# Altium schematic sheet charger.SchDoc
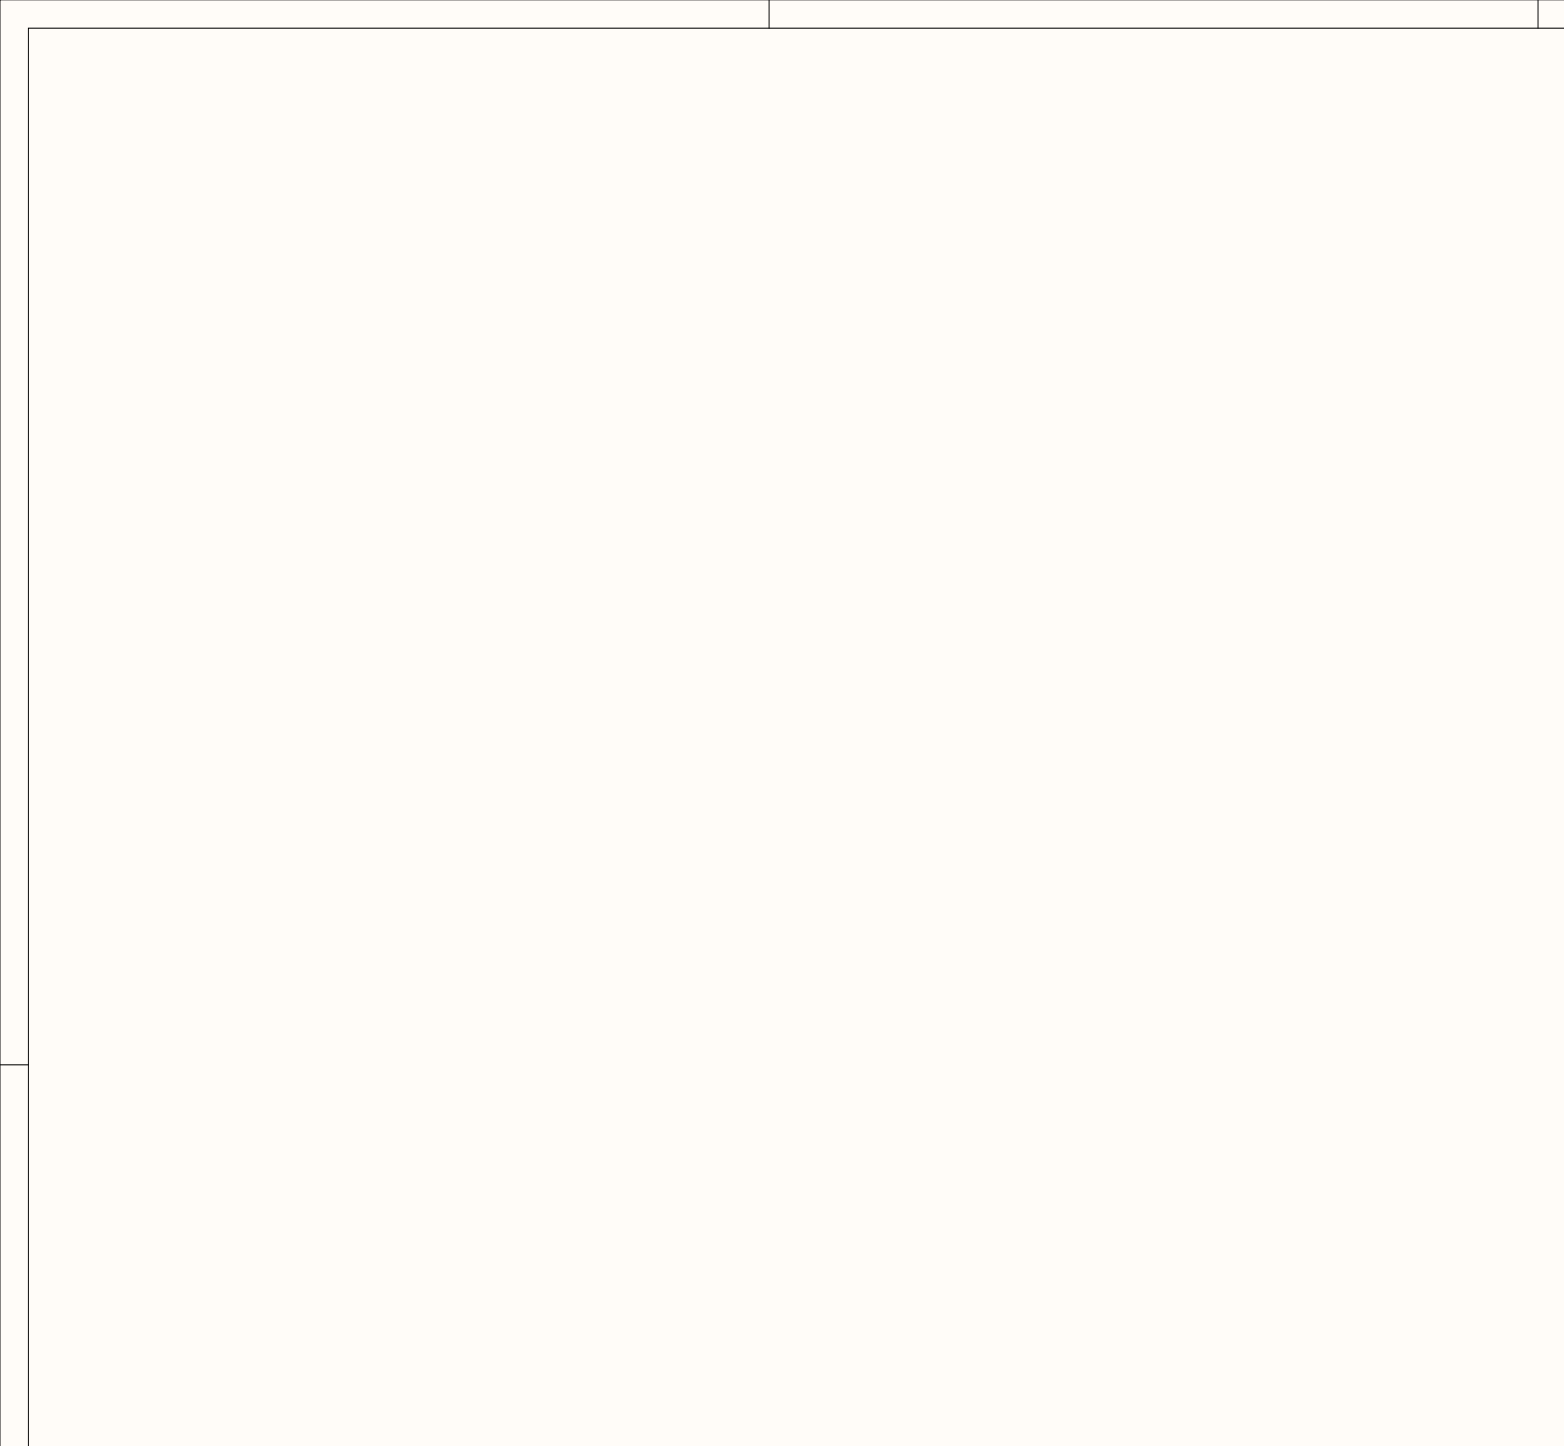
[diagram p0: charger.SchDoc, top left]
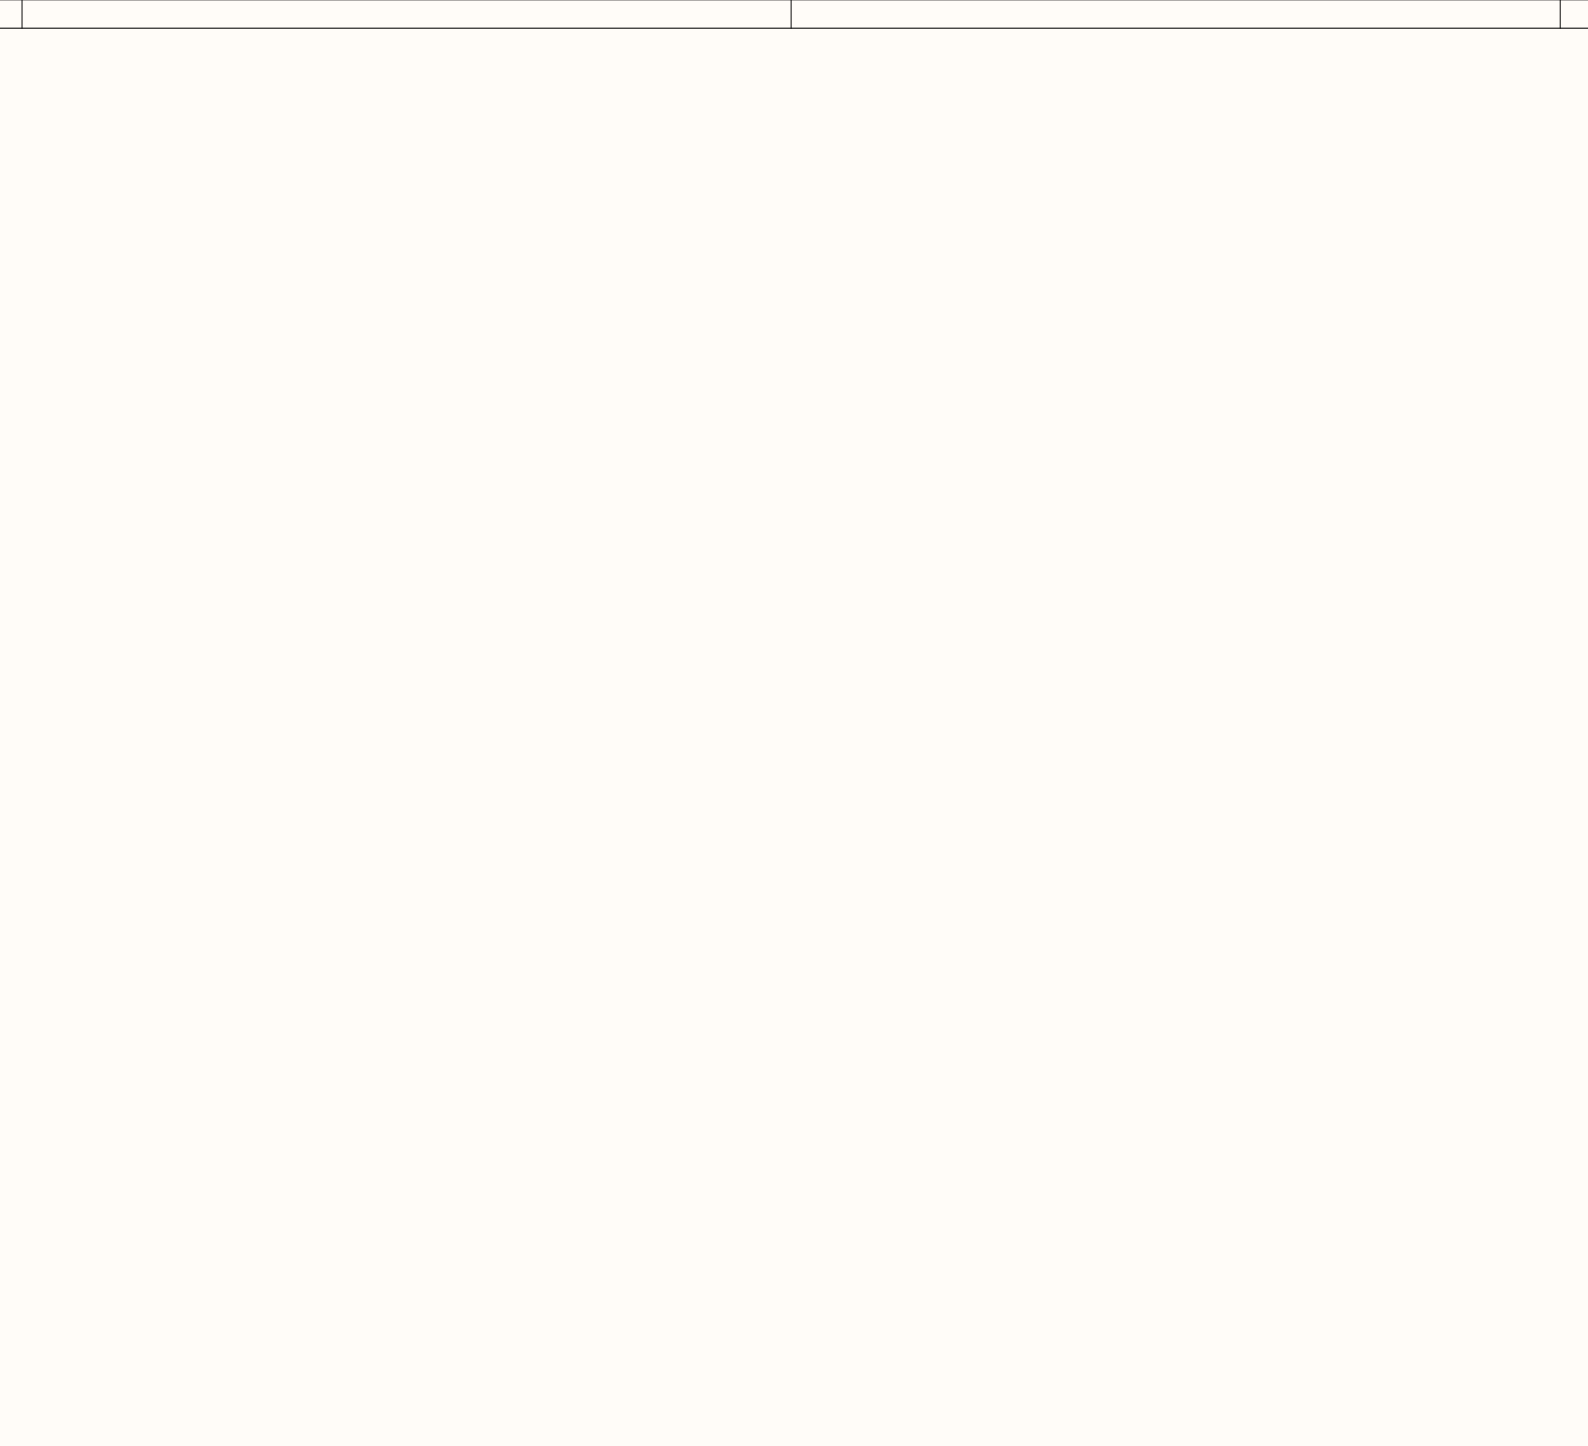
[diagram p1: charger.SchDoc, top centre]
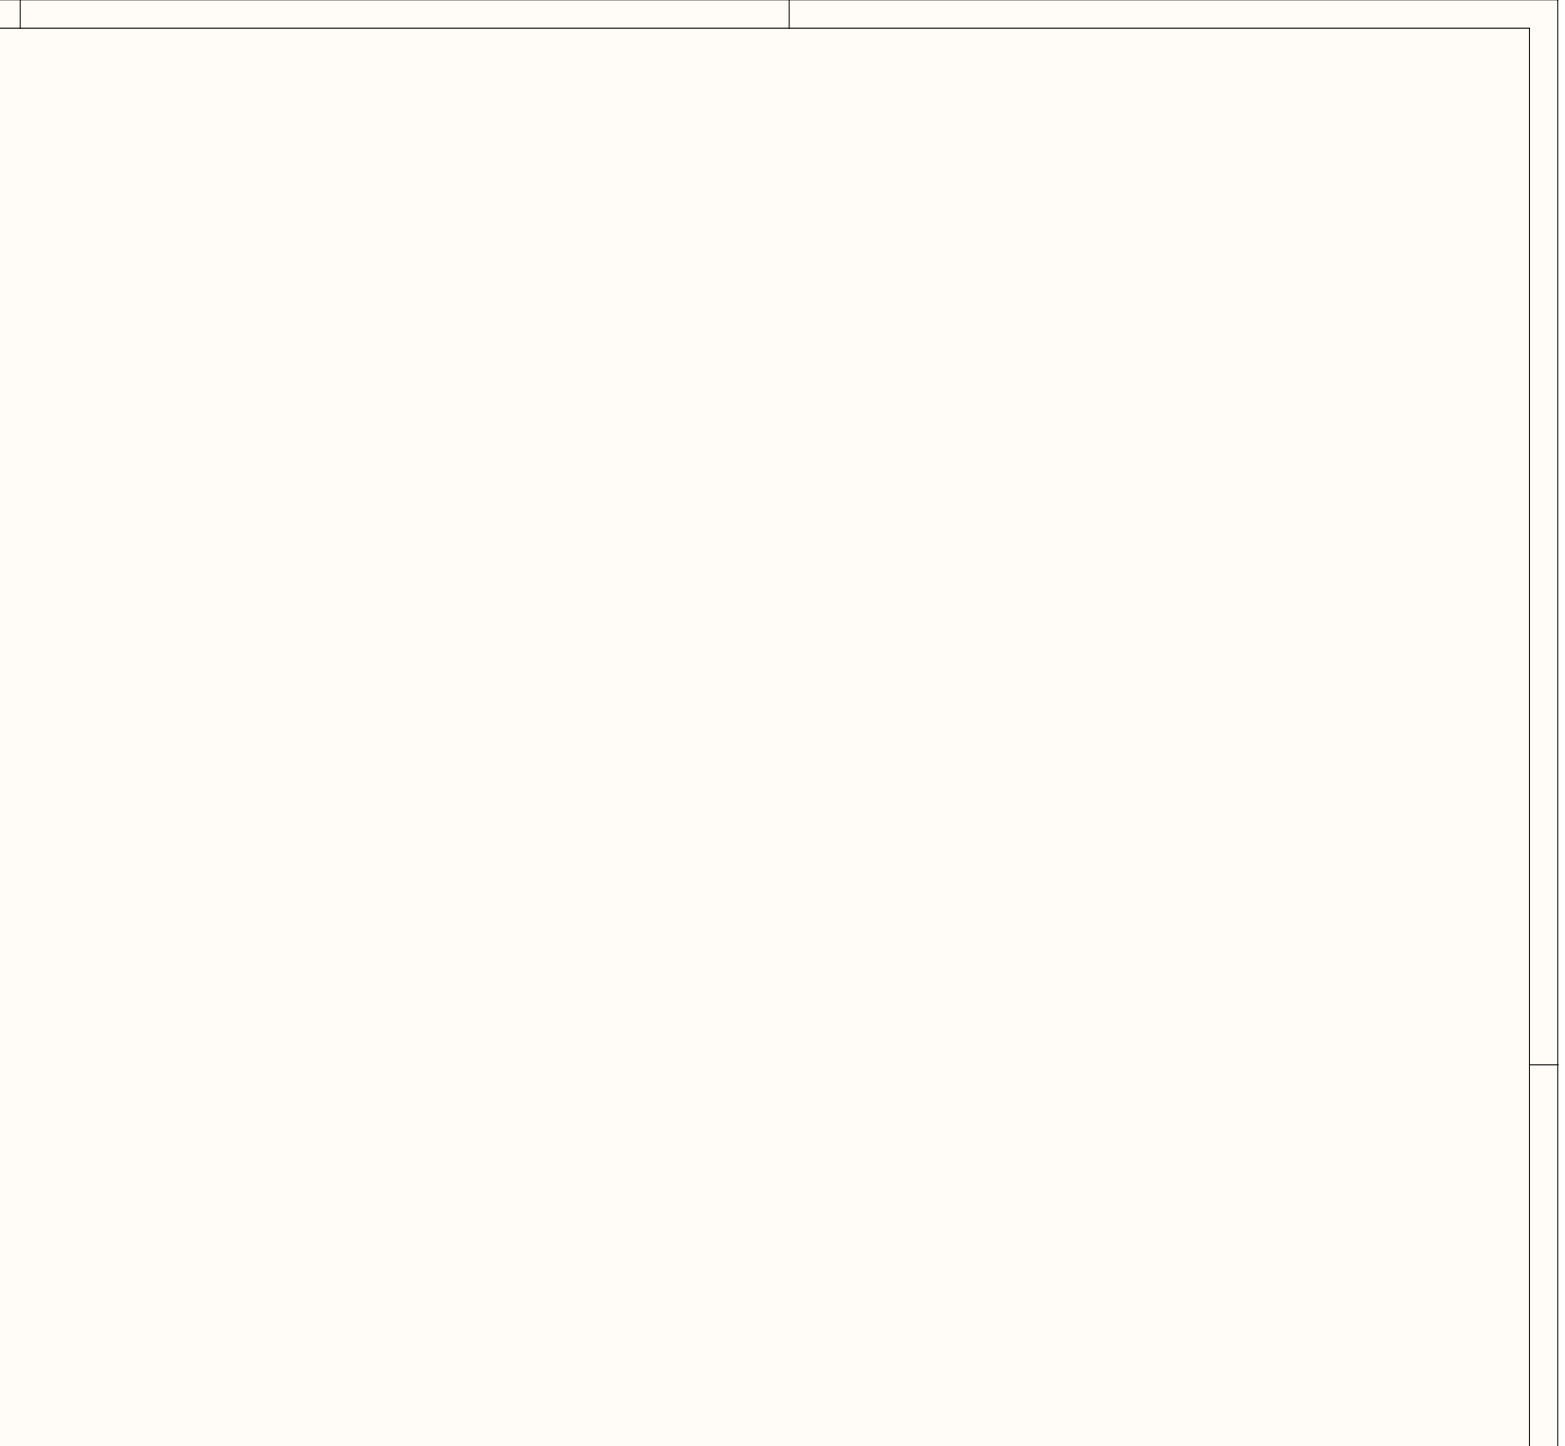
[diagram p2: charger.SchDoc, top right]
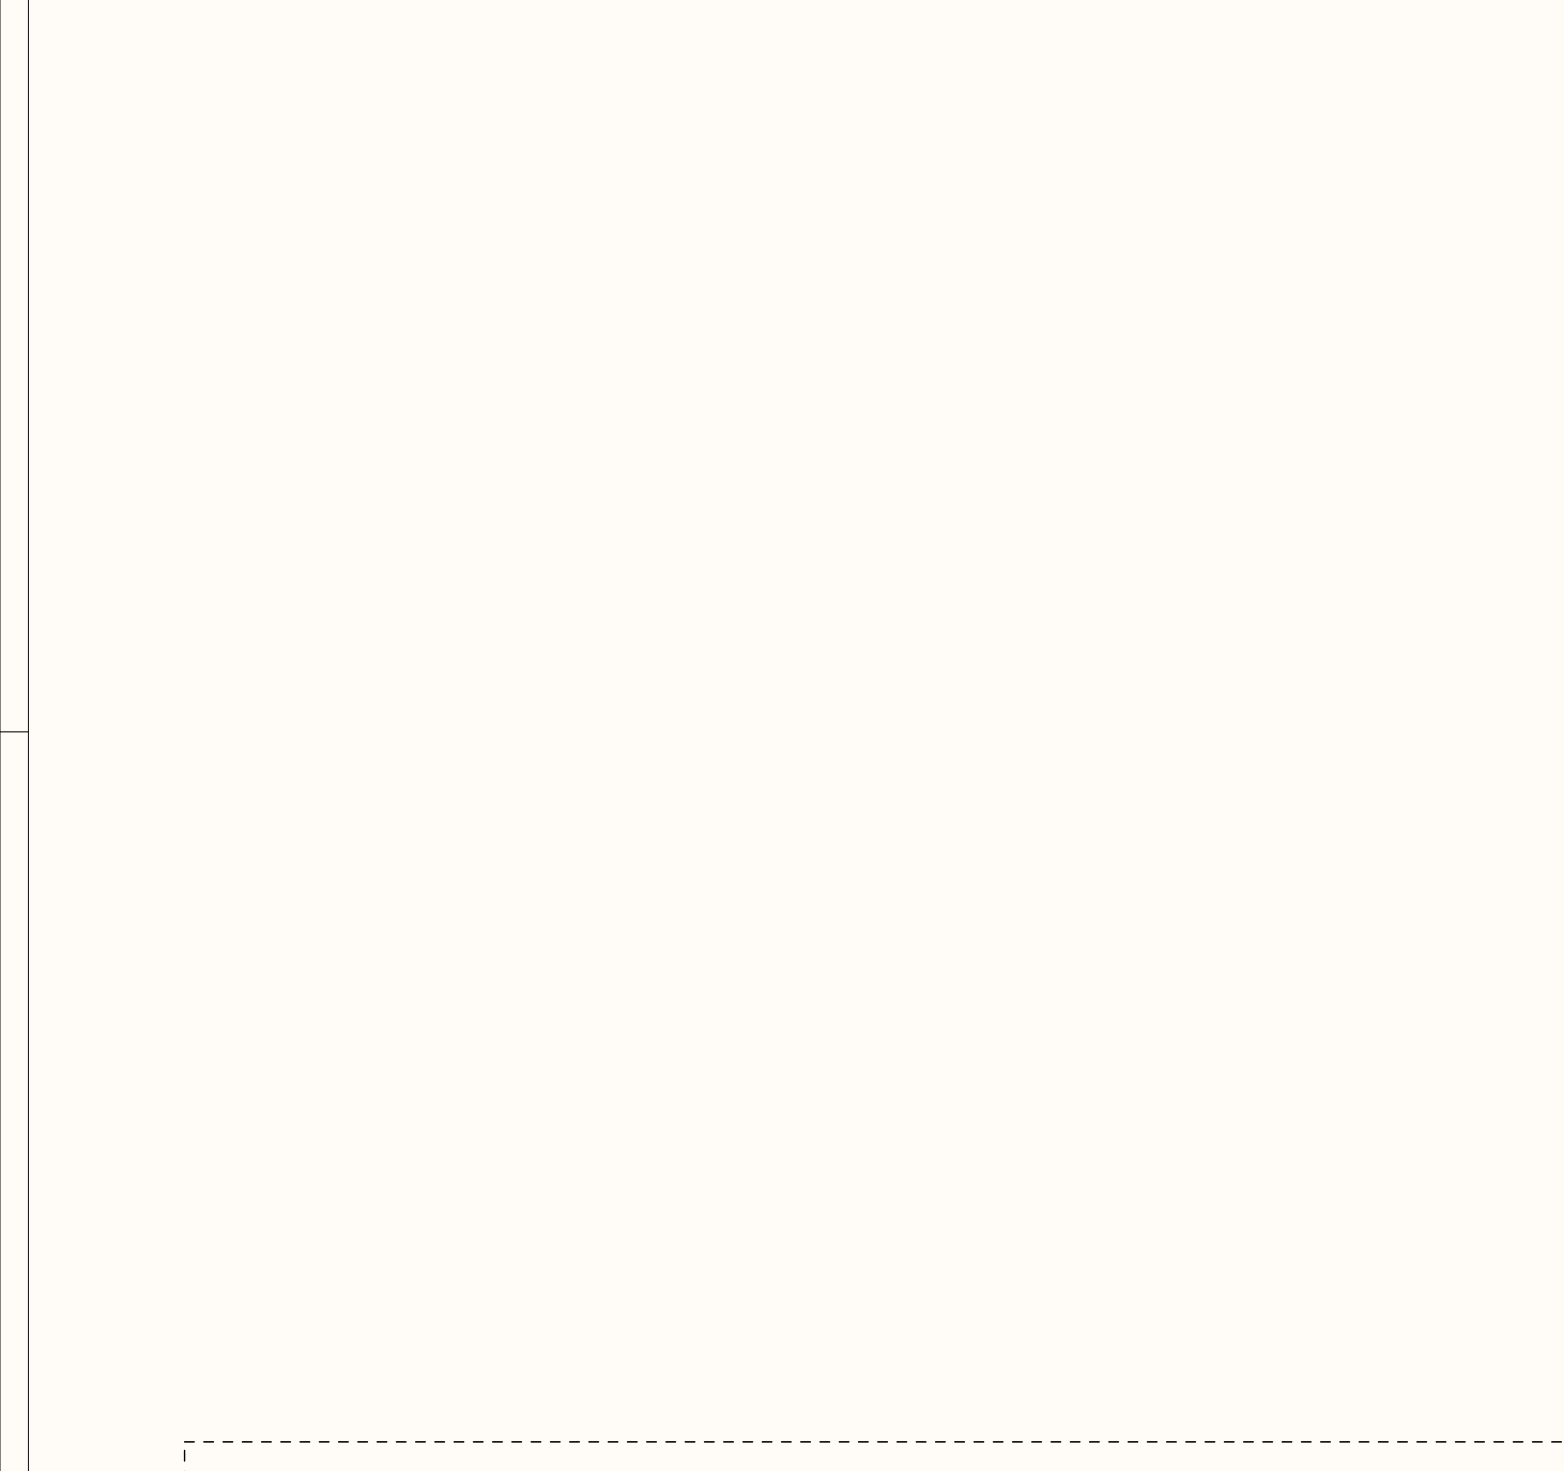
[diagram p3: charger.SchDoc, middle left]
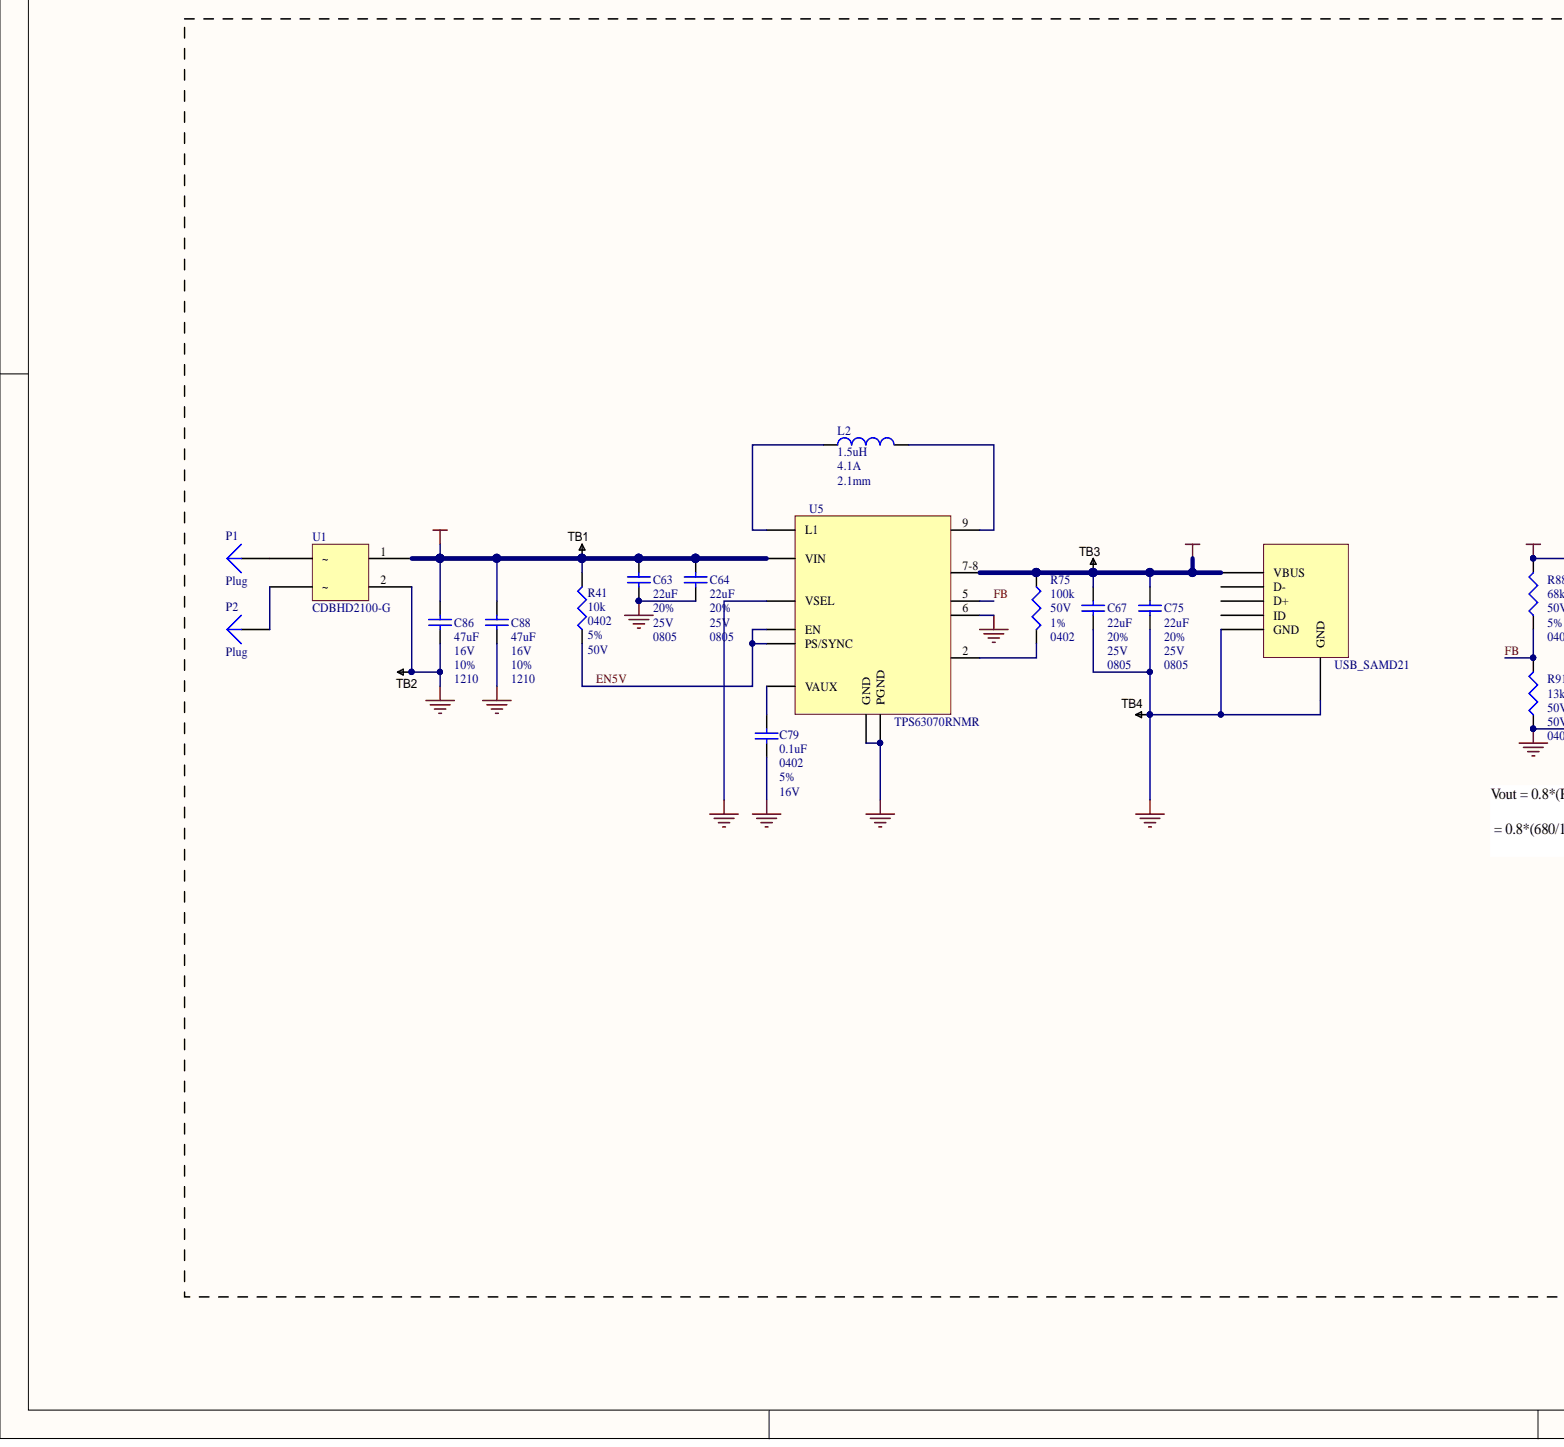
[diagram p4: charger.SchDoc, bottom left]
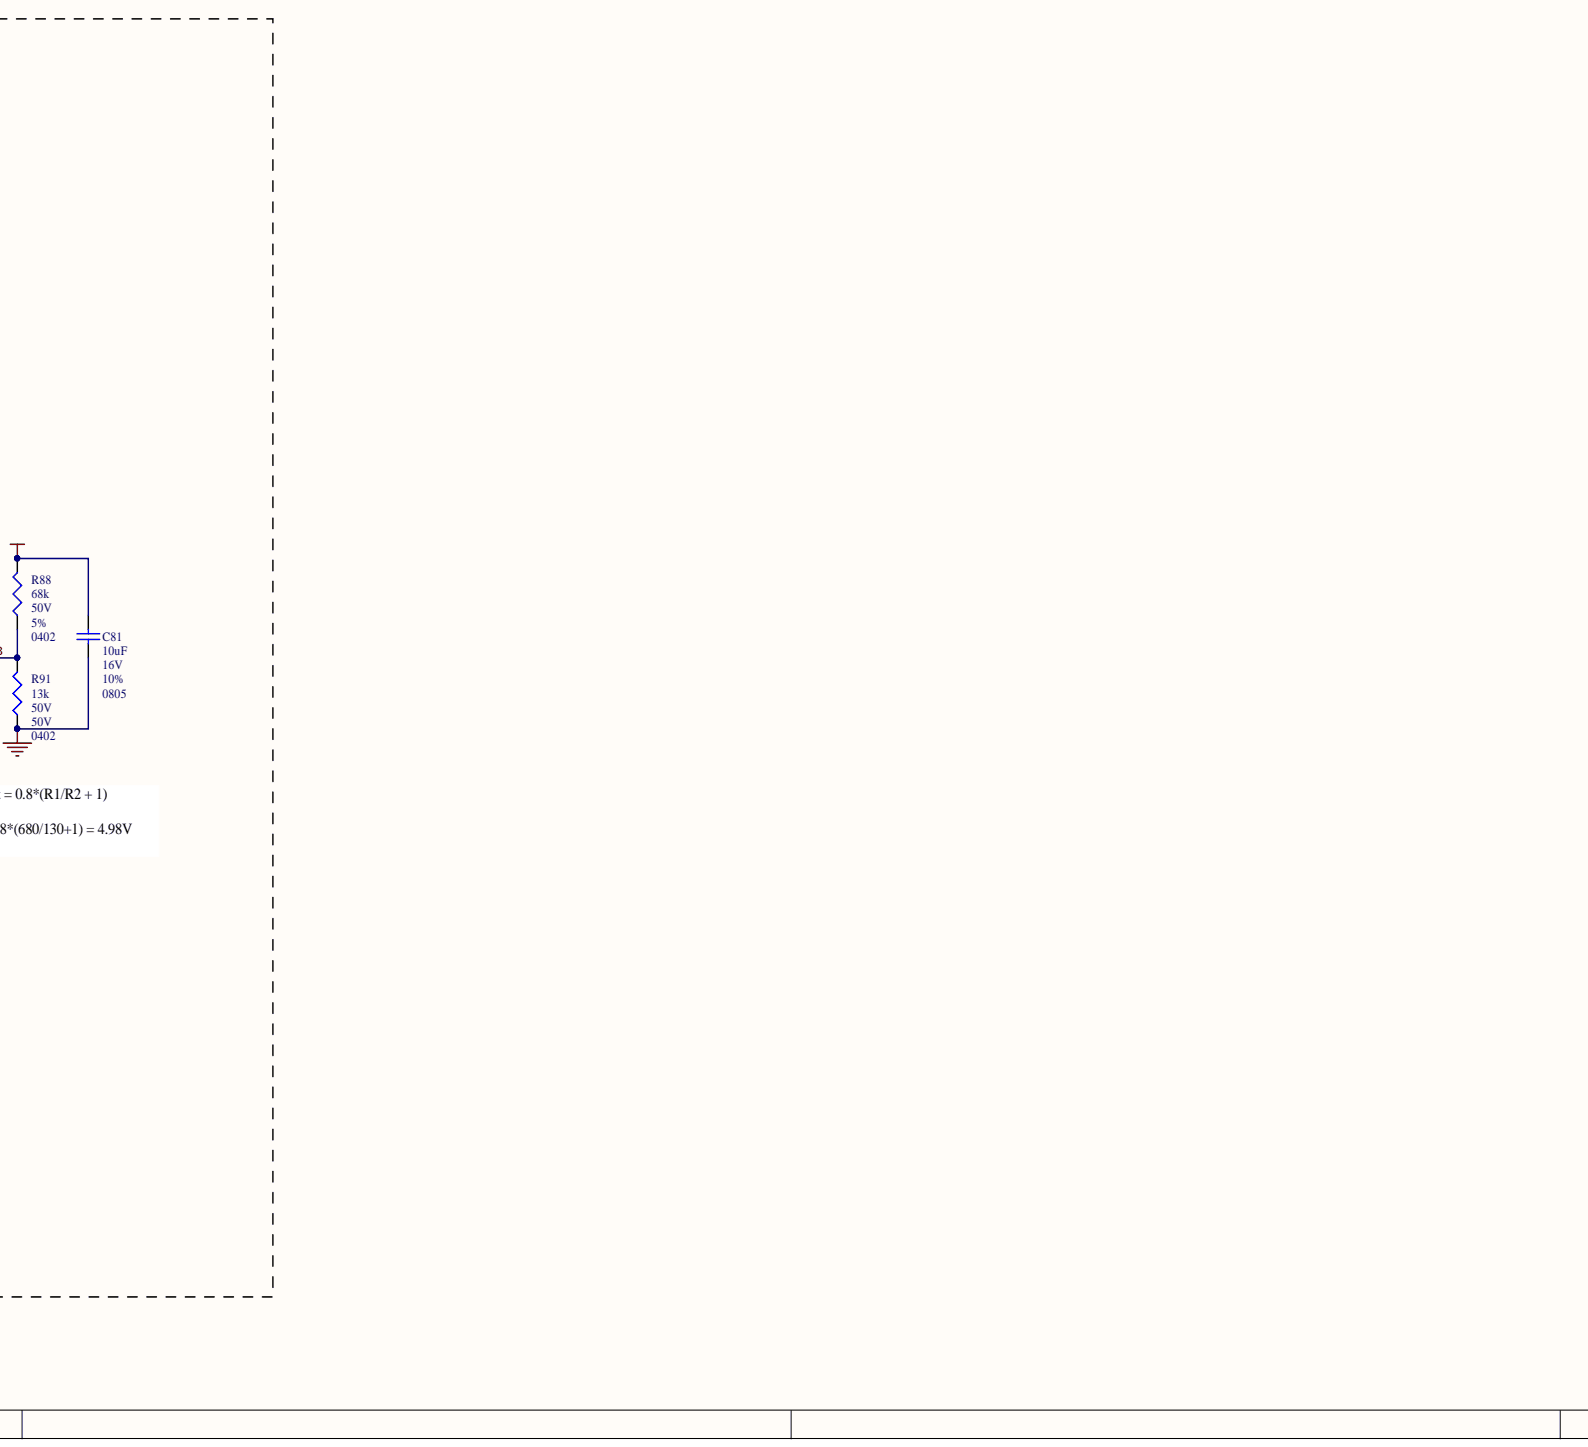
[diagram p5: charger.SchDoc, bottom centre]
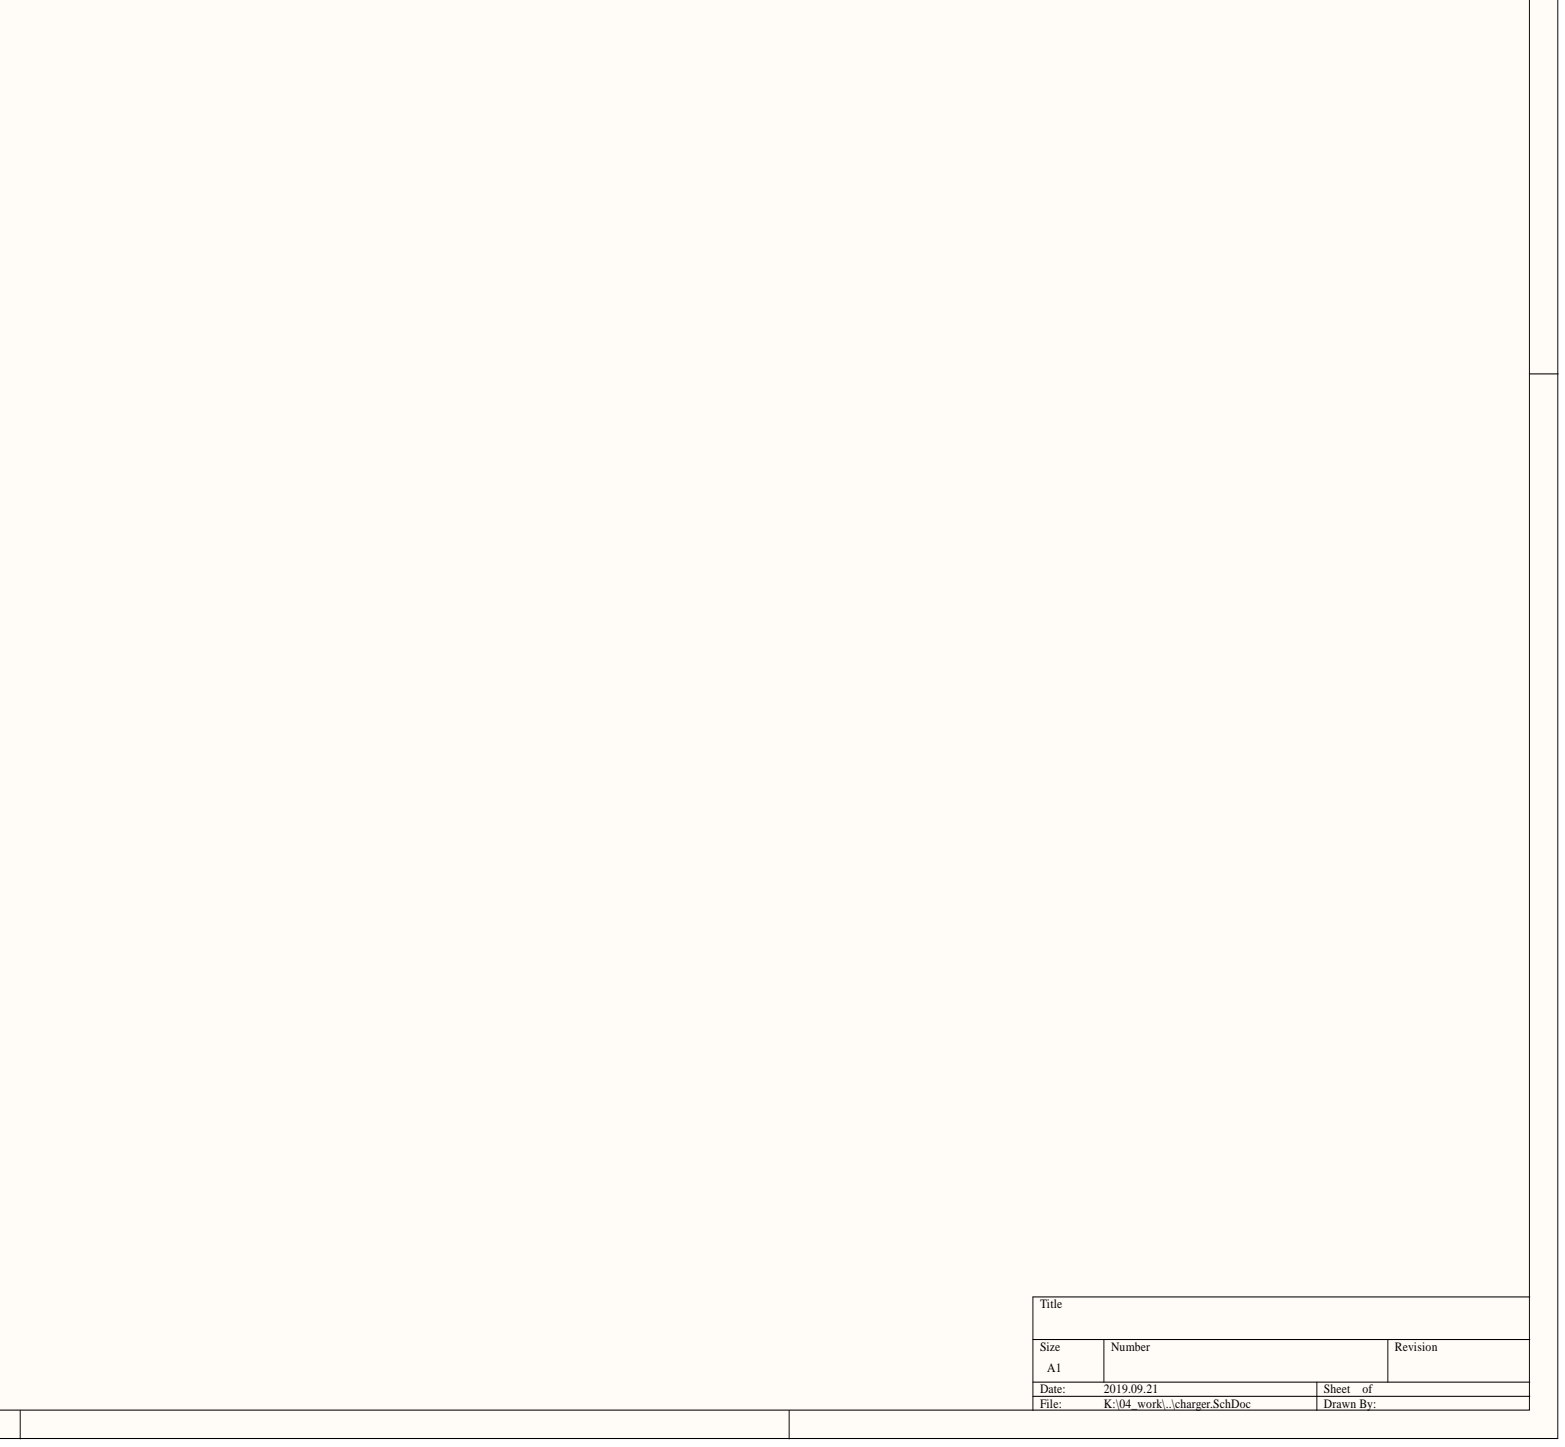
[diagram p6: charger.SchDoc, bottom right]

## Parts
C63  value 22uF  Capacitance: 22uF  Case/Package: 0805  Tolerance: 20%  Voltage Rating: 25V  [C0805]  (hidden comment: Cap Semi)
C64  value 22uF  Capacitance: 22uF  Case/Package: 0805  Tolerance: 20%  Voltage Rating: 25V  [C0805]  (hidden comment: Cap Semi)
C67  value 22uF  Capacitance: 22uF  Case/Package: 0805  Tolerance: 20%  Voltage Rating: 25V  [C0805]  (hidden comment: Cap Semi)
C75  value 22uF  Capacitance: 22uF  Case/Package: 0805  Tolerance: 20%  Voltage Rating: 25V  [C0805]  (hidden comment: Cap Semi)
C79  value 0.1uF  Case/Package: 0402  Tolerance: 5%  Voltage Rating: 16V  [C0402]  (hidden comment: Cap Semi)
C81  value 10uF  Capacitance: 10uF  Case/Package: 0805  Tolerance: 10%  Voltage: 16V  [C0805]  (hidden comment: Cap Semi)
C86  value 47uF  Capacitance: 47uF  Case/Package: 1210  Tolerance: 10%  Voltage: 16V  [C1210]  (hidden comment: Cap Semi)
C88  value 47uF  Capacitance: 47uF  Case/Package: 1210  Tolerance: 10%  Voltage: 16V  [C1210]  (hidden comment: Cap Semi)
L2  value 1.5uH  Height: 2.1mm  Saturation Current: 4.1A  [INDM4040X210]  (hidden comment: Inductor)
P1  Plug  [Pogo_RightAngle]
P2  Plug  [Pogo_RightAngle]
R41  value 10k  Case/Package: 0402  Tolerance: 5%  Voltage Rating: 50V  [R0402]  (hidden comment: Res3)
R75  value 100k  Case/Package: 0402  Tolerance: 1%  Voltage Rating: 50V  [R0402]  (hidden comment: Res3)
R88  value 68k  Case/Package: 0402  Tolerance: 5%  Voltage Rating: 50V  [R0402]  (hidden comment: Res3)
R91  value 13k  Case/Package: 0402  Voltage Rating: 50V  [R0402]  (hidden comment: Res3)
TB1  TESTPOINT  [TP-R40]  (value not drawn)  (no BOM)
TB2  TESTPOINT  [TP-R40]  (value not drawn)  (no BOM)
TB3  TESTPOINT  [TP-R40]  (value not drawn)  (no BOM)
TB4  TESTPOINT  [TP-R40]  (value not drawn)  (no BOM)
U1  CDBHD2100-G  [CDBHD2100-G]
U5  TPS63070RNMR  [QFN50P250X300X100-15N_TPS63070RNMR(Primary)]
USB_SAMD21  USB MICRO SMD  [MicroUSB B Plug]  (value not drawn)

## Nets
GND: C63.1 C64.1 C67.1 C75.1 C79.2 C81.1 C86.1 C88.1 R91.1 TB2.1 TB4.1 U1.2 U5.4(GND) U5.6 U5.10(PGND) U5.15(VSEL) USB_SAMD21.5(GND) USB_SAMD21.6(GND)
VCC12V: C63.2 C64.2 C86.2 C88.2 R41.2 TB1.1 U1.1 U5.12-13(VIN)
VDD5V: C67.2 C75.2 C81.2 R75.1 R88.2 TB3.1 U5.7-8 USB_SAMD21.1(VBUS)
EN5V: R41.1 U5.1(PS/SYNC) U5.14(EN)
FB: R88.1 R91.2 U5.5
NetC79_1: C79.1 U5.3(VAUX)
NetL2_1: L2.1 U5.11(L1)
NetL2_2: L2.2 U5.9
NetP1_1: P1.1 U1.4(~)
NetP2_1: P2.1 U1.3(~)
NetR75_2: R75.2 U5.2

Not connected: USB_SAMD21 2(D-) 3(D+) 4(ID)

## Library data of the parts
C63 C64 C67 C75 = Cap Semi: Capacitor (Semiconductor SIM Model); Manufacturer: Murata; Manufacturer Part Number: GRM21BR61E226ME44K; Dielectric: X5R; Case Code (Imperial): 0805; Case Code (Metric): 2012; Component Kind: Standard; Composition: Ceramic; Height: 1.25mm; Length: 0.079inch; Library Name: Miscellaneous Devices.IntLib; Max Operating Temperature: 85°C; Min Operating Temperature: -55°C; Mount: Surface Mount; Package Quantity: 10000; Packaging: Tape and Reel; Pricing 1: 1=0.49, 10=0.348, 100=0.2291, 500=0.1697, 1000=0.1443, 2500=0.1358, 5000=0.1273 (USD); Signal Integrity: Cap Semi; Simulation: CAP; Stock 1: 36449; Supplier 1: Digi-Key; Supplier Part Number 1: 490-14661-1-ND; Termination: SMD/SMT; Thickness: 0.057inch; Voltage Rating (DC): 25V; Weight: 0.000335oz; Width: 1.25mm
C79 = Cap Semi: Capacitor (Semiconductor SIM Model); Manufacturer: Yageo; Manufacturer Part Number: CC0402JRX7R7BB104; Capacitance: 100nF; Dielectric: X7R; Case Code (Imperial): 0402; Case Code (Metric): 1005; Component Kind: Standard; ComponentLink1Description: http://www.mouser.com/ds/2/447/Yageo_UPY-GP_NP0_16V-to-50V_9-358835.pdf; ComponentLink1URL: http://www.mouser.com/ds/2/447/Yageo_UPY-GP_NP0_16V-to-50V_9-358835.pdf; ComponentLink2Description: http://www.yageo.com/documents/recent/UPY-GPHC_X7R_6.3V-to-50V_8.pdf; ComponentLink2URL: http://www.yageo.com/documents/recent/UPY-GPHC_X7R_6.3V-to-50V_8.pdf; Composition: Ceramic; Depth: 0.5mm; Halogen Free: Halogen Free; Height: 0.5mm; Lead Free: Lead Free; Length: 1mm; Library Name: Miscellaneous Devices.IntLib; Max Operating Temperature: 125°C; Min Operating Temperature: -55°C; Mount: Surface Mount; Package Quantity: 10000; Packaging: Tape and Reel; Pricing 1: 1=0.1, 10=0.057, 100=0.0256, 500=0.0183, 1000=0.0144, 2500=0.0131, 5000=0.012 (USD); Radiation Hardening: No; Signal Integrity: Cap Semi; Simulation: CAP; Stock 1: 874792; Supplier 1: Digi-Key; Supplier Part Number 1: 311-1676-1-ND; Thickness: 0.022inch; Voltage Rating (DC): 16V; Width: 0.02inch
C81 = Cap Semi: Capacitor; Manufacturer: Samsung; Manufacturer Part Number: CL21A106KOQNNNG; Dielectric: X5R; Material: Ceramic; Case Code (Imperial): 0805; Case Code (Metric): 2012; Component Kind: Standard; ComponentLink1Description: http://www.samsungsem.com/kr/support/product-search/mlcc/CL21A106KOQNNNG.jsp; ComponentLink1URL: http://www.samsungsem.com/kr/support/product-search/mlcc/CL21A106KOQNNNG.jsp; ComponentLink2Description: https://produktinfo.conrad.com/datenblaetter/450000-474999/454932-da-01-en-VS_KONDENSATOR_0805.pdf; ComponentLink2URL: https://produktinfo.conrad.com/datenblaetter/450000-474999/454932-da-01-en-VS_KONDENSATOR_0805.pdf; Depth: 1.25mm; Height: 1.25mm; Length: 2mm; Library Name: Miscellaneous Devices.IntLib; Max Operating Temperature: 85°C; Min Operating Temperature: -55°C; Mount: Surface Mount; Package Quantity: 3000; Packaging: Tape and Reel; Pricing 1: 1=0.14, 10=0.099, 100=0.0463, 500=0.0325, 1000=0.0269 (USD); Signal Integrity: Cap Semi; Simulation: CAP; Stock 1: 30000; Supplier 1: Digi-Key; Supplier Part Number 1: 1276-6455-1-ND; Thickness: 0.053inch; Voltage Rating: 16V; Width: 0.049inch
C86 C88 = Cap Semi: Capacitor (Semiconductor SIM Model); Manufacturer: Murata; Manufacturer Part Number: GRM32EC81C476KE15L; Dielectric: X6S; Material: Ceramic; Case Code (Imperial): 1210; Case Code (Metric): 3225; Component Kind: Standard; ComponentLink1Description: http://www.farnell.com/datasheets/2048597.pdf?src-supplier=Element14; ComponentLink1URL: http://www.farnell.com/datasheets/2048597.pdf?src-supplier=Element14; Depth: 2.5mm; Height: 2.5mm; Lead Free: Lead Free; Length: 3.2mm; Library Name: Miscellaneous Devices.IntLib; Max Operating Temperature: 105°C; Min Operating Temperature: -55°C; Mount: Surface Mount; Package Quantity: 1000; Packaging: Tape and Reel; Pricing 1: 1=0.78, 10=0.571, 100=0.3917, 500=0.3101 (USD); REACH SVHC: No SVHC; Signal Integrity: Cap Semi; Simulation: CAP; Stock 1: 39077; Supplier 1: Digi-Key; Supplier Part Number 1: 490-10531-1-ND; Termination: SMD/SMT; Thickness: 0.106inch; Voltage Rating: 16V; Voltage Rating (DC): 16V; Weight: 0.00388oz; Width: 2.5mm
L2 = Inductor: Manufacturer: Coilcraft; Manufacturer Part Number: XFL4020-152MEC; Core Material: Composite; DC Resistance (DCR): 15.8mR; Inductance Tolerance: 20%; Max DC Current: 9.1A; Self Resonant Frequency: 59MHz; Shielding: Shielded; Test Frequency: 100kHz; Case/Package: SMD/SMT; Component Kind: Standard; ComponentLink1Description: http://www.mouser.com/ds/2/597/xfl4020-270756.pdf; ComponentLink1URL: http://www.mouser.com/ds/2/597/xfl4020-270756.pdf; ComponentLink2Description: http://www.farnell.com/datasheets/1682010.pdf; ComponentLink2URL: http://www.farnell.com/datasheets/1682010.pdf; Description: Inductor; Inductance: 1.5uh; Lead Free: Contains Lead; Length: 4.3mm; Library Name: Miscellaneous Devices.IntLib; Max Operating Temperature: 125°C; Min Operating Temperature: -40°C; Mount: Surface Mount; Packaging: Tape and Reel; Pricing 1: 1=1.99, 10=1.99, 50=1.99, 100=1.59, 1000=0.885, 10000=0.885 (USD); REACH SVHC: No SVHC; RMS Current (Irms): 9.1A; Signal Integrity: Inductor; Simulation: INDUCTOR; Stock 1: 28664; Supplier 1: Mouser; Supplier Part Number 1: 994-XFL4020-152MEC; Termination: SMD/SMT; Tolerance: 20%; Width: 4.3mm
R41 = Res3: Resistor; Manufacturer: TE Connectivity; Manufacturer Part Number: CRG0402J10K/10; Number of Resistors: 1; Power Rating: 63mW; Resistance: 10kR; Temperature Coefficient: 200ppm/°C; Case Code (Imperial): 0402; Case Code (Metric): 1005; Component Kind: Standard; ComponentLink1Description: http://uk.rs-online.com/webdocs/13e2/0900766b813e23cb.pdf; ComponentLink1URL: http://uk.rs-online.com/webdocs/13e2/0900766b813e23cb.pdf; ComponentLink2Description: http://www.farnell.com/datasheets/1610603.pdf; ComponentLink2URL: http://www.farnell.com/datasheets/1610603.pdf; ComponentLink3Description: http://www.mouser.com/ds/2/418/NG_CD_1622826_BA1-677535.pdf; ComponentLink3URL: http://www.mouser.com/ds/2/418/NG_CD_1622826_BA1-677535.pdf; ComponentLink4Description: http://www.te.com/commerce/DocumentDelivery/DDEController?Action=showdoc&DocId=Data+Sheet%7F1773204%7FF%7Fpdf%7FEnglish%7FENG_DS_1773204_F.pdf; ComponentLink4URL: http://www.te.com/commerce/DocumentDelivery/DDEController?Action=showdoc&DocId=Data+Sheet%7F1773204%7FF%7Fpdf%7FEnglish%7FENG_DS_1773204_F.pdf; Composition: Thick Film; Depth: 0.5mm; ELV: Compliant; Height: 0.35mm; Length: 1mm; Library Name: Miscellaneous Devices.IntLib; Max Operating Temperature: 125°C; Min Operating Temperature: -55°C; Mount: Surface Mount; Number of Terminations: 2; Package Quantity: 10000; Packaging: Tape and Reel; Pricing 2: 1=0.1, 10=0.016, 100=0.0064, 1000=0.0029, 2500=0.0025, 5000=0.0021 (USD); Radiation Hardening: No; REACH SVHC: Unknown; Signal Integrity: Res; Simulation: RESISTOR; Stock 2: 164304; Supplier 1: Digi-Key; Supplier 2: Digi-Key; Supplier Part Number 1: 311-10KJRCT-ND; Supplier Part Number 2: A106045CT-ND; Termination: Solder; Voltage Rating (DC): 50V; Weight: 2.3E-05oz; Width: 0.5mm
R75 = Res3: Resistor; Manufacturer: Yageo; Manufacturer Part Number: RC0402FR-07100KL; Max Power Dissipation: 63mW; Resistance: 100kR; Temperature Coefficient: 100ppm/°C; Case Code (Imperial): 0402; Case Code (Metric): 1005; Component Kind: Standard; ComponentLink1Description: http://www.yageo.com/documents/recent/PYu-RC_Group_51_RoHS_L_10.pdf?src-supplier=Digi-Key; ComponentLink1URL: http://www.yageo.com/documents/recent/PYu-RC_Group_51_RoHS_L_10.pdf?src-supplier=Digi-Key; ComponentLink2Description: http://www.farnell.com/datasheets/1018594.pdf?src-supplier=Element14; ComponentLink2URL: http://www.farnell.com/datasheets/1018594.pdf?src-supplier=Element14; ComponentLink3Description: http://www.yageo.com/documents/recent/PYu-RC_Group_51_RoHS_L_9.pdf?src-supplier=Verical; ComponentLink3URL: http://www.yageo.com/documents/recent/PYu-RC_Group_51_RoHS_L_9.pdf?src-supplier=Verical; ComponentLink4Description: http://www.yageo.com/documents/recent/PYu-RC_Group_51_RoHS_L_9.pdf?src-supplier=Mouser; ComponentLink4URL: http://www.yageo.com/documents/recent/PYu-RC_Group_51_RoHS_L_9.pdf?src-supplier=Mouser; ComponentLink5Description: http://documents.rs-components.com/techlib://itc_doclist_GB.htm?stockno=6185797&src-supplier=RSComponents; ComponentLink5URL: http://documents.rs-components.com/techlib://itc_doclist_GB.htm?stockno=6185797&src-supplier=RSComponents; Composition: Thick Film; Depth: 0.5mm; Features: Moisture Resistant; Height: 0.016inch; Lead Free: Lead Free; Length: 0.039inch; Library Name: Miscellaneous Devices.IntLib; Max Operating Temperature: 155°C; Military Standard: Not; Min Operating Temperature: -55°C; Number of Terminations: 2; Package Quantity: 1; Packaging: Tape and Reel; Power Rating: 63mW; Pricing 1: 1=0.1, 10=0.016, 100=0.0067, 1000=0.003, 2500=0.0026, 5000=0.0022 (USD); REACH SVHC: No SVHC; Signal Integrity: Res; Simulation: RESISTOR; Stock 1: 62177550; Supplier 1: Digi-Key; Supplier Part Number 1: 311-100KLRCT-ND; Termination: SMD/SMT; Width: 0.02inch; Working Voltage: 50V
R88 = Res3: Resistor; Manufacturer: Yageo; Manufacturer Part Number: RC0402JR-0768KL; Max Power Dissipation: 63mW; Resistance: 68kR; Temperature Coefficient: 100ppm/°C; Case Code (Imperial): 0402; Case Code (Metric): 1005; Component Kind: Standard; ComponentLink1Description: http://www.yageo.com/documents/recent/PYu-RC_Group_51_RoHS_L_9.pdf; ComponentLink1URL: http://www.yageo.com/documents/recent/PYu-RC_Group_51_RoHS_L_9.pdf; ComponentLink2Description: http://www.yageo.com/documents/recent/PYu-RC_Group_51_RoHS_L_10.pdf; ComponentLink2URL: http://www.yageo.com/documents/recent/PYu-RC_Group_51_RoHS_L_10.pdf; ComponentLink3Description: http://www.farnell.com/datasheets/1018594.pdf; ComponentLink3URL: http://www.farnell.com/datasheets/1018594.pdf; Composition: Thick Film; Depth: 0.5mm; Features: Moisture Resistant; Height: 0.016inch; Lead Free: Lead Free; Length: 1mm; Library Name: Miscellaneous Devices.IntLib; Max Operating Temperature: 155°C; Military Standard: Not; Min Operating Temperature: -55°C; Number of Pins: 2; Number of Terminations: 2; Package Quantity: 1; Packaging: Tape and Reel; Power Rating: 63mW; Pricing 1: 1=0.1, 10=0.013, 100=0.0055, 1000=0.0025, 2500=0.0021, 5000=0.0018 (USD); REACH SVHC: No SVHC; Signal Integrity: Res; Simulation: RESISTOR; Stock 1: 2047315; Supplier 1: Digi-Key; Supplier Part Number 1: 311-68KJRCT-ND; Termination: SMD/SMT; Voltage Rating (DC): 50V; Width: 0.02inch; Working Voltage: 50V
R91 = Res3: Resistor; Manufacturer: Yageo; Manufacturer Part Number: RC0402FR-0713KL; Max Power Dissipation: 63mW; Power Rating: 62.5mW; Resistance: 13kR; Temperature Coefficient: 100ppm/°C; Tolerance: 1%; Case Code (Imperial): 0402; Case Code (Metric): 1005; Component Kind: Standard; ComponentLink1Description: http://www.yageo.com/documents/recent/PYu-RC_Group_51_RoHS_L_9.pdf; ComponentLink1URL: http://www.yageo.com/documents/recent/PYu-RC_Group_51_RoHS_L_9.pdf; ComponentLink2Description: http://www.yageo.com/documents/recent/PYu-RC_Group_51_RoHS_L_10.pdf; ComponentLink2URL: http://www.yageo.com/documents/recent/PYu-RC_Group_51_RoHS_L_10.pdf; ComponentLink3Description: http://documents.rs-components.com/techlib://itc_doclist_GB.htm?stockno=6185961; ComponentLink3URL: http://documents.rs-components.com/techlib://itc_doclist_GB.htm?stockno=6185961; ComponentLink4Description: http://www.mouser.com/ds/2/447/PYu-RC0402_51_RoHS_L_6_r-8721.pdf; ComponentLink4URL: http://www.mouser.com/ds/2/447/PYu-RC0402_51_RoHS_L_6_r-8721.pdf; Composition: Thick Film; Depth: 0.5mm; Features: Moisture Resistant; Height: 0.016inch; Lead Free: Lead Free; Length: 1mm; Library Name: Miscellaneous Devices.IntLib; Max Operating Temperature: 155°C; Military Standard: Not; Min Operating Temperature: -55°C; Mount: Surface Mount; Number of Terminations: 2; Package Quantity: 10000; Packaging: Tape and Reel; Pricing 1: 1=0.1, 10=0.016, 100=0.0067, 1000=0.003, 2500=0.0026, 5000=0.0022 (USD); Signal Integrity: Res; Simulation: RESISTOR; Stock 1: 1193115; Supplier 1: Digi-Key; Supplier Part Number 1: 311-13.0KLRCT-ND; Termination: SMD/SMT; Voltage Rating (DC): 50V; Width: 0.02inch; Working Voltage: 50V
TB1-TB4 = TESTPOINT: SMD test pin; Library Name: PBL - Test Points.SCHLIB
U1 = DIODE_BRIDGE_100V_2A: DIODE BRIDGE 2A 100V TO-269AA; Manufacturer: Comchip; Max Reverse Leakage Current: 500uA; Max Surge Current: 50A; Component Kind: Standard; ComponentLink1Description: http://media.digikey.com/PDF/Data%20Sheets/Comchip%20PDFs/CDBHD280-G%20thru%202100-G.PDF?src-supplier=Digi-Key; ComponentLink1URL: http://media.digikey.com/PDF/Data%20Sheets/Comchip%20PDFs/CDBHD280-G%20thru%202100-G.PDF?src-supplier=Digi-Key; ComponentLink2Description: http://www.comchiptech.com/admin/files/product/CDBHD240-G%20Thru.%20CDBHD2100-G%20%20%20%20%20RevC.PDF?src-supplier=Digi-Key; ComponentLink2URL: http://www.comchiptech.com/admin/files/product/CDBHD240-G%20Thru.%20CDBHD2100-G%20%20%20%20%20RevC.PDF?src-supplier=Digi-Key; ComponentLink3Description: http://www.mouser.com/ds/2/80/CDBHD240-G%20Thru.%20CDBHD2100-G%20%20%20%20%20RevC-766412.pdf?src-supplier=Mouser; ComponentLink3URL: http://www.mouser.com/ds/2/80/CDBHD240-G%20Thru.%20CDBHD2100-G%20%20%20%20%20RevC-766412.pdf?src-supplier=Mouser; Library Name: Schlib1.SchLib; Manufacturer Part Number: CDBHD2100-G; Mount: Surface Mount; Package Quantity: 2500; Packaging: Tape and Reel; Pricing 1: 1=1.26, 10=1.125, 25=1.068, 100=0.8772, 250=0.82, 500=0.7246, 1000=0.5721 (USD); Stock 1: 28798; Supplier 1: Digi-Key; Supplier Part Number 1: 641-1212-1-ND
U5 = TPS63070RNMR: Wide Input Voltage _2V-16V_ Buck-Boost Converter 15-VQFN-HR; Manufacturer: Texas Instruments; Max Input Voltage: 16V; Max Output Voltage: 9V; Min Input Voltage: 2V; Min Output Voltage: 2.5V; Number of Outputs: 1; Output Configuration: Positive; Output Current: 2A; Output Type: Adjustable; Quiescent Current: 50uA; Switching Frequency: 2.4MHz; Synchronous Rectifier: Yes; Case/Package: VQFN; Component Kind: Standard; Digi-Key_Part_Number: 296-44874-1-ND; Digi-Key_Purchase_URL: https://www.digikey.com/product-detail/en/texas-instruments/TPS63070RNMR/296-44874-1-ND/6217416?utm_source=snapeda&utm_medium=aggregator&utm_campaign=symbol; Lead Free: Lead Free; Library Name: Schlib1.SchLib; Manufacturer Part Number: TPS63070RNMR; Max Operating Temperature: 125°C; MF: Texas Instruments; Min Operating Temperature: -40°C; Mount: Surface Mount; MP: TPS63070RNMR; Number of Pins: 15; Package: VQFN-15 Texas Instruments; Packaging: Tape and Reel; Pricing 1: 1=2.67, 10=2.402, 100=1.9306, 500=1.5862, 1000=1.3143 (USD); SnapEDA_Link: https://www.snapeda.com/parts/TPS63070RNMR/Texas%20Instruments/view-part/?ref=snap; Stock 1: 31678; Supplier 1: Digi-Key; Supplier Part Number 1: 296-44874-1-ND; Topology: Buck-Boost
USB_SAMD21 = USB MICRO SMD: CONN RCPT USB MICRO AB SMD R/A; Component Kind: Standard; Library Name: Schlib1.SchLib
P1 P2 = Plug: Component Kind: Standard; Description: Plug; LatestRevisionDate: 17-Jul-2002; LatestRevisionNote: Re-released for DXP Platform.; Library Name: Miscellaneous Connectors.IntLib; Signal Integrity: Connector; Publisher: Altium Limited
